# Altium schematic sheet OpenChill_Main_Board.SchDoc(OpenChill_Main_Board)
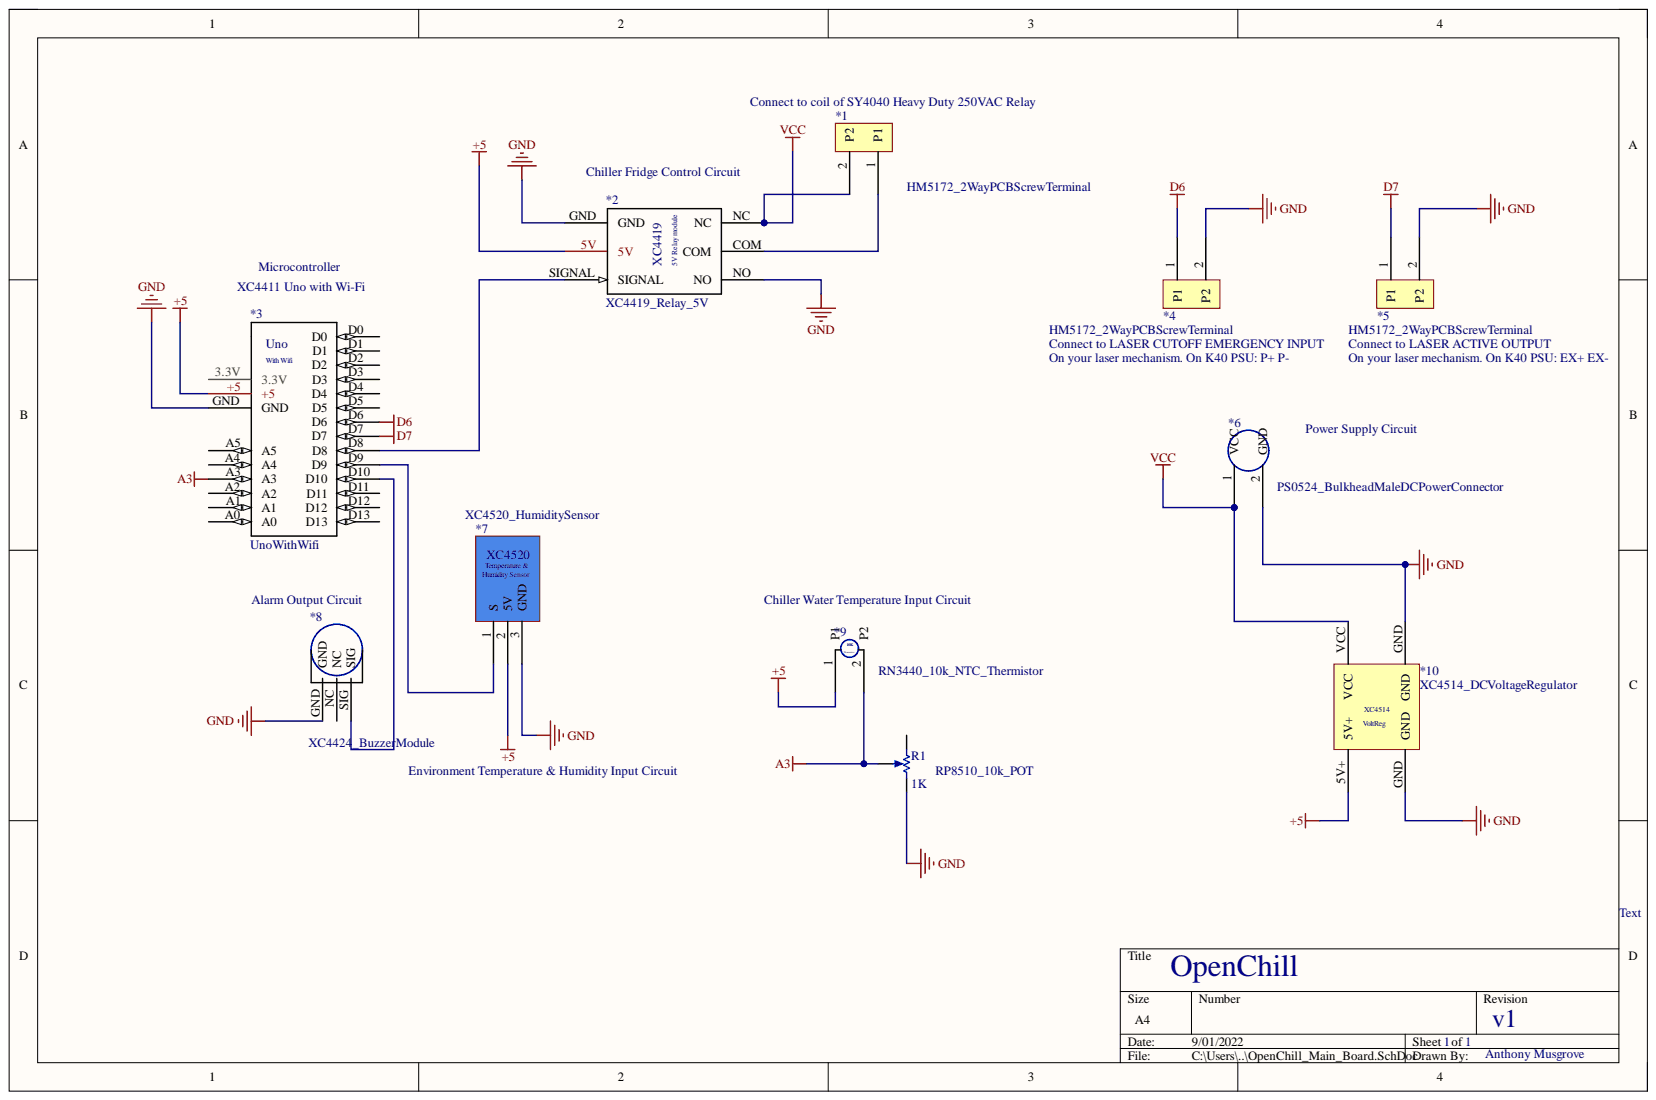
[diagram p0: OpenChill_Main_Board.SchDoc]

## Parts
*1  HM5172_2WayPCBScrewTerminal  [OpenChill_2xThruHole]
*2  XC4419_Relay_5V  [XC4419_5VRelay_Footprint]
*3  UnoWithWifi  [ARDUINO_INTERFACE_FOOTPRINT]
*4  HM5172_2WayPCBScrewTerminal  [OpenChill_2xThruHole]
*5  HM5172_2WayPCBScrewTerminal  [OpenChill_2xThruHole]
*6  PS0524_BulkheadMaleDCPowerConnector  [OpenChill_2xThruHole]
*7  XC4520_HumiditySensor  [OpenChill_3xThruHole]
*8  XC4424_BuzzerModule  [XC4424_BuzzerModule_Footprint]
*9  RN3440_10k_NTC_Thermistor  [OpenChill_2xThruHole]
*10  XC4514_DCVoltageRegulator  [XC4514_VoltReg_Footprint]
R1  RP8510_10k_POT  value 1K  [VR5]

## Nets
+5: *2.5V *3.+5 *7.2 *9.1 *10.5V+
A3: *3.A3 *9.2 R1.3
D6: *3.D6 *4.1
D7: *3.D7 *5.1
GND: *2.GND *2.NO *3.GND *4.2 *5.2 *6.2 *7.3 *8.GND *10.GND R1.2
VCC: *1.2 *2.NC *6.1 *10.VCC
Net*1_1: *1.1 *2.COM
Net*2_SIGNAL: *2.SIGNAL *3.D8
Net*3_D9: *3.D9 *7.1
Net*3_D10: *3.D10 *8.SIG

Not connected: *3 3.3V A0 A1 A2 A4 A5 D0 D1 D2 D3 D4 D5 D11 D12 D13; *8 NC; R1 1

## Library data of the parts
*4 = HM5172_2WayPCBScrewTerminal: LASER CUTOFF EMERGENCY; Component Kind: Standard; Library Name: OpenChill_Components_Library.SchLib
*5 = HM5172_2WayPCBScrewTerminal: LASER ACTIVE; Component Kind: Standard; Library Name: OpenChill_Components_Library.SchLib
R1 = RPot: Potentiometer; Set Position: 0.5; Sim Note: Enter the Resistance value and the set point into parameter fields.; Component Kind: Standard; LatestRevisionDate: 17-Jul-2002; LatestRevisionNote: Re-released for DXP Platform.; Library Name: Miscellaneous Devices.IntLib; PackageDescription: Variable Resistor, Thru-Hole; 3 Leads; PackageReference: VR5; Published: 8-Jun-2000; Simulation: POT; Publisher: Altium Limited
*1 = HM5172_2WayPCBScrewTerminal: Component Kind: Standard; Library Name: OpenChill_Components_Library.SchLib
*2 = XC4419_Relay_5V: Component Kind: Standard; Library Name: OpenChill_Components_Library.SchLib
*3 = UnoWithWifi: Component Kind: Standard; Library Name: OpenChill_Components_Library.SchLib
*6 = PS0524_BulkheadMaleDCPowerConnector: Component Kind: Standard; Library Name: OpenChill_Components_Library.SchLib
*7 = XC4520_HumiditySensor: Component Kind: Standard; Library Name: OpenChill_Components_Library.SchLib
*8 = XC4424_BuzzerModule: Component Kind: Standard; Library Name: OpenChill_Components_Library.SchLib
*9 = RN3440_10k_NTC_Thermistor: Component Kind: Standard; Library Name: OpenChill_Components_Library.SchLib
*10 = XC4514_DCVoltageRegulator: Component Kind: Standard; Library Name: OpenChill_Components_Library.SchLib
